# Altium schematic sheet TemperatureSensor.SchDoc
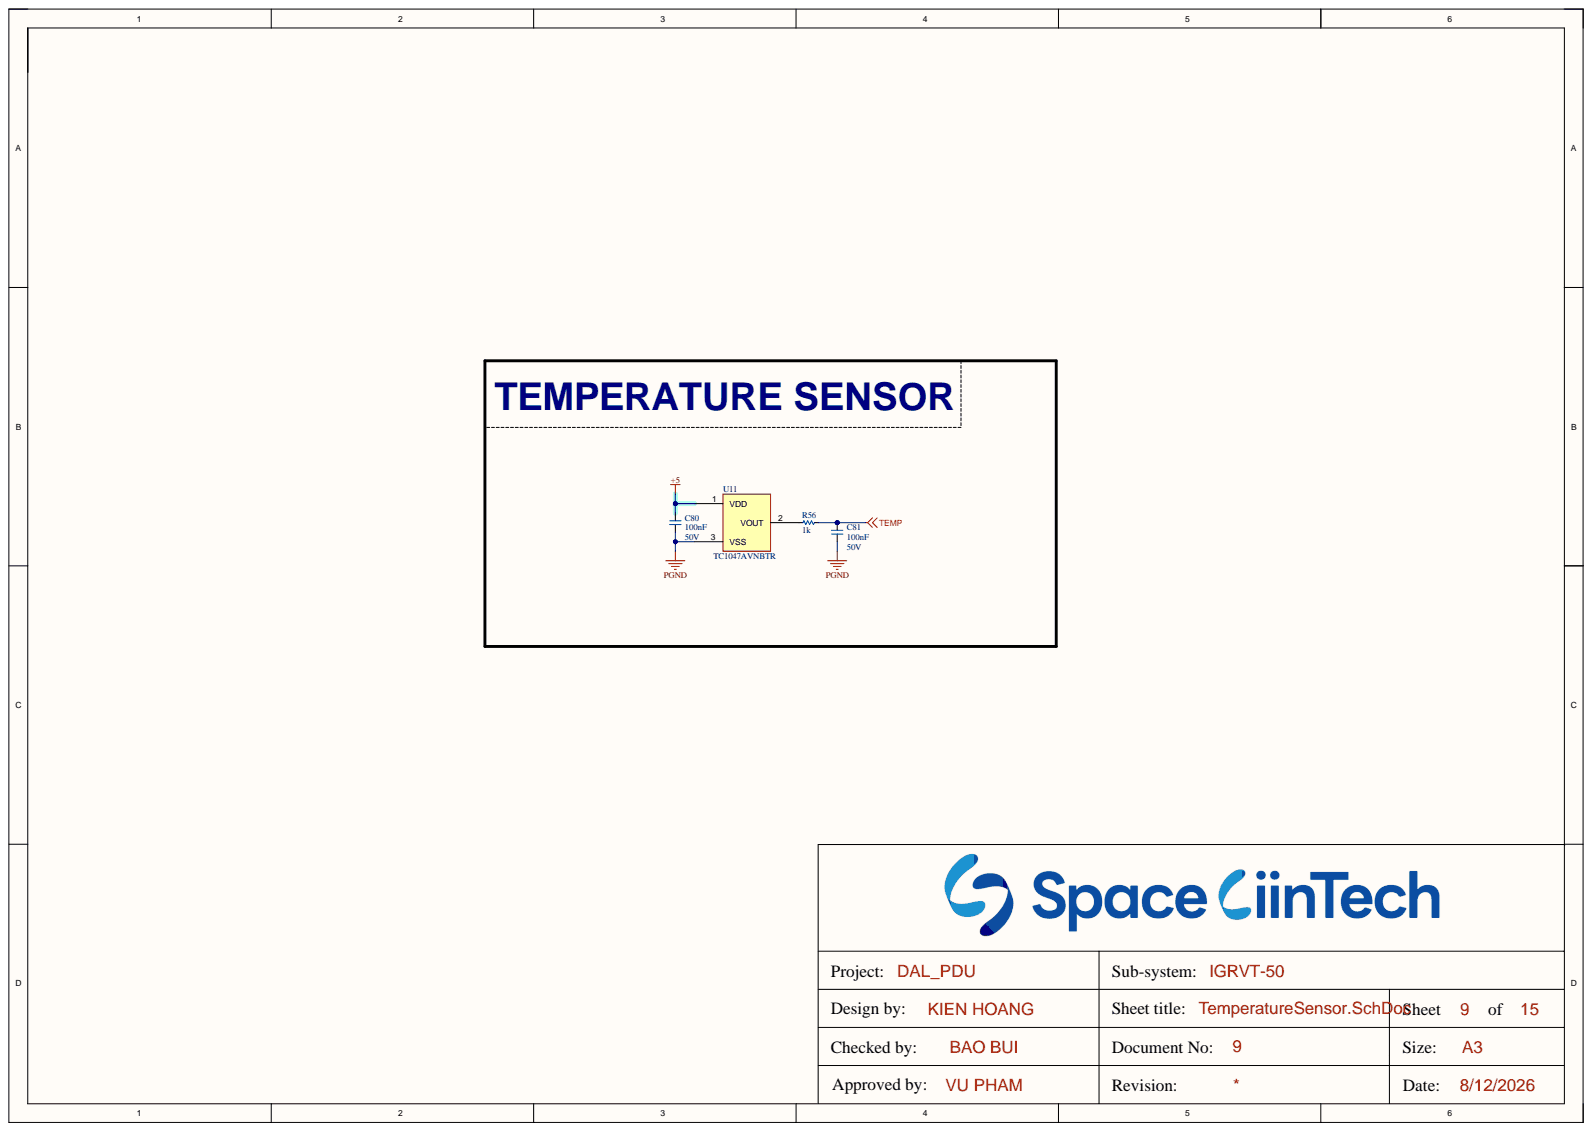
[diagram p0: TemperatureSensor.SchDoc]

## Parts
C80  100nF  Voltage: 50V  [CAP_C_1608X80]
C81  100nF  Voltage: 50V  [CAP_C_1608X80]
R56  1k  [RES_C_1608X60]
U11  TC1047AVNBTR  [SOT-23]

## Nets
+5: C80.1 U11.1
PGND: C80.2 C81.2 U11.3
TEMP: C81.1 R56.2
NetR56_1: R56.1 U11.2

## Library data of the parts
C80 C81 = _CAP_C_2: CAP; Component Kind: Standard; Library Name: LABLIB.SchLib
R56 = _RES_B_2_2: RES; Component Kind: Standard; Library Name: LABLIB.SchLib
U11 = TC1047AVNBTR: Component Kind: Standard; Library Name: KIENASIY123_LIB.IntLib
